# Altium schematic sheet Status Light Control.SchDoc
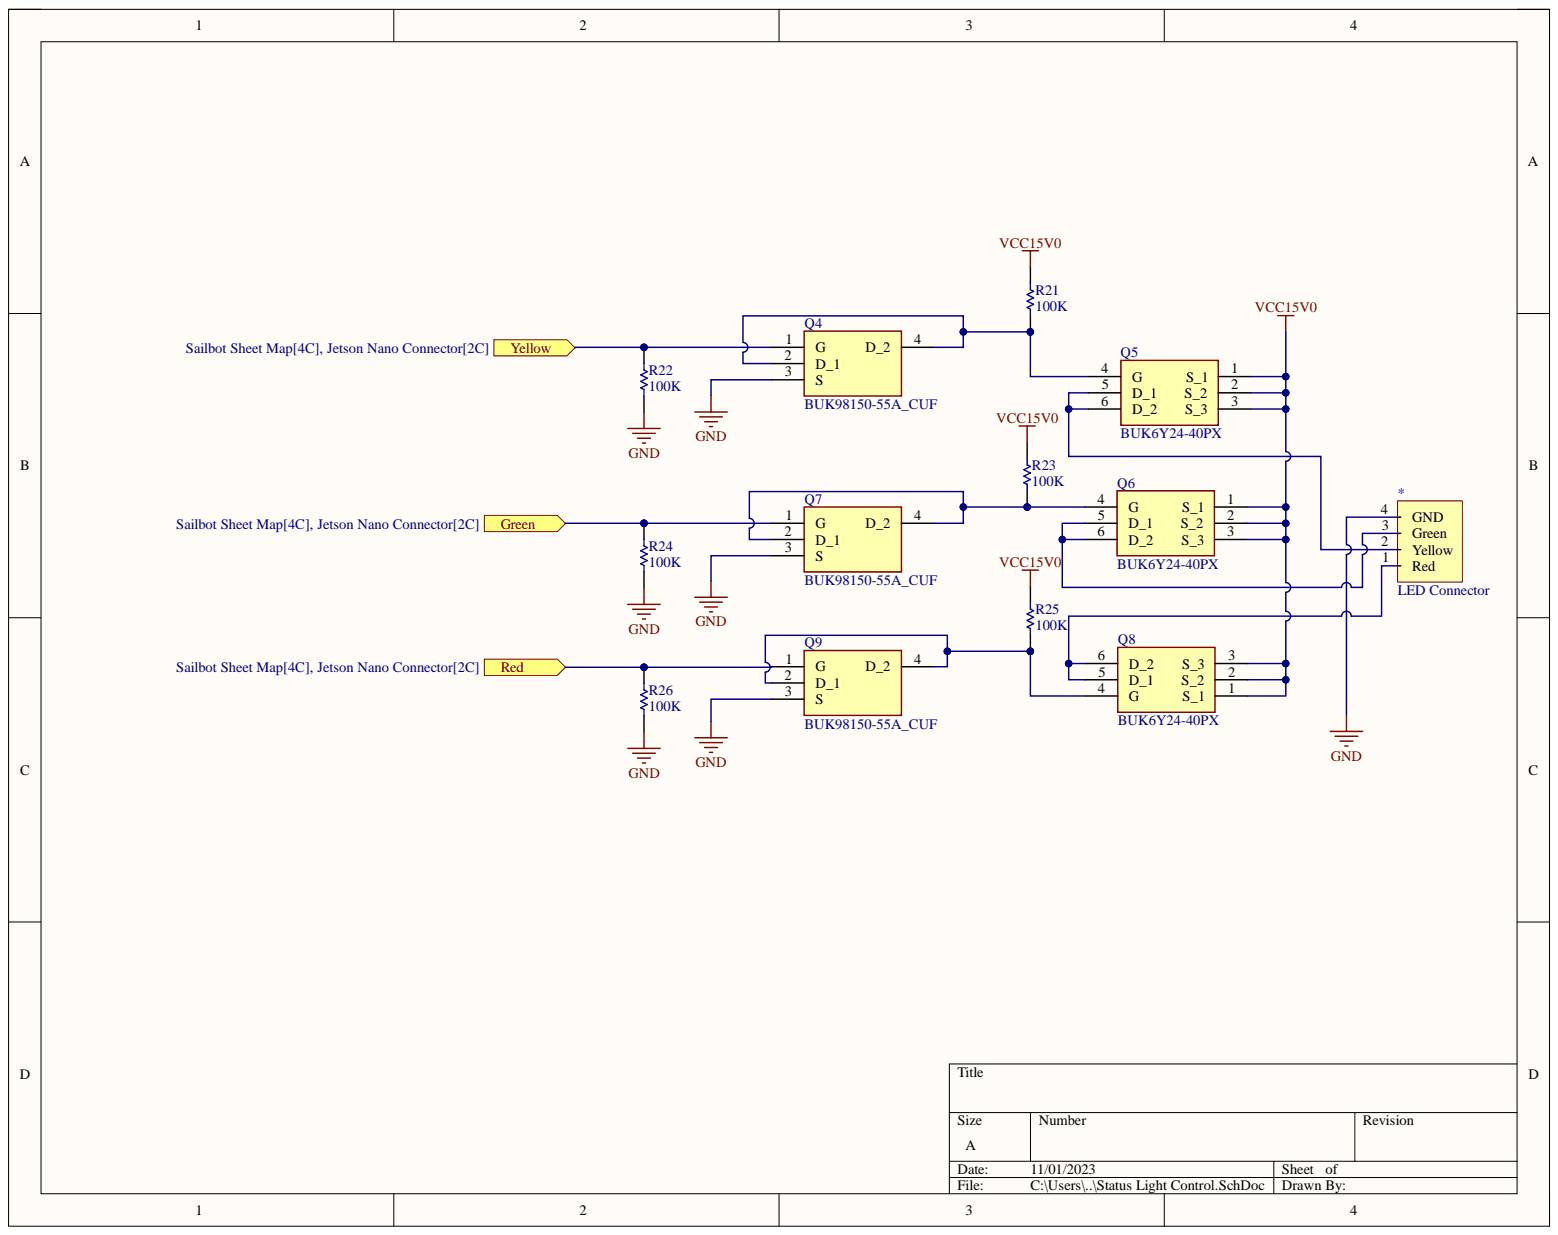
[diagram p0: Status Light Control.SchDoc]

## Parts
*  LED Connector
Q4  BUK98150-55A_CUF  [SOT230P700X180-4N]
Q5  BUK6Y24-40PX  [BUK6Y2440PX]
Q6  BUK6Y24-40PX  [BUK6Y2440PX]
Q7  BUK98150-55A_CUF  [SOT230P700X180-4N]
Q8  BUK6Y24-40PX  [BUK6Y2440PX]
Q9  BUK98150-55A_CUF  [SOT230P700X180-4N]
R21  value 100K  (hidden comment: Resistor)
R22  value 100K  (hidden comment: Resistor)
R23  value 100K  (hidden comment: Resistor)
R24  value 100K  (hidden comment: Resistor)
R25  value 100K  (hidden comment: Resistor)
R26  value 100K  (hidden comment: Resistor)

## Ports
Green, Red, Yellow

## Nets
GND: *.4(GND) Q4.3(S) Q7.3(S) Q9.3(S) R22.1 R24.1 R26.1
VCC15V0: Q5.1(S_1) Q5.2(S_2) Q5.3(S_3) Q6.1(S_1) Q6.2(S_2) Q6.3(S_3) Q8.1(S_1) Q8.2(S_2) Q8.3(S_3) R21.2 R23.2 R25.2
Green: Q7.1(G) R24.2 ; port Green
Red: Q9.1(G) R26.2 ; port Red
Yellow: Q4.1(G) R22.2 ; port Yellow
Net*_1: *.1(Red) Q8.5(D_1) Q8.6(D_2)
Net*_2: *.2(Yellow) Q5.5(D_1) Q5.6(D_2)
Net*_3: *.3(Green) Q6.5(D_1) Q6.6(D_2)
NetQ4_2: Q4.2(D_1) Q4.4(D_2) Q5.4(G) R21.1
NetQ6_4: Q6.4(G) Q7.2(D_1) Q7.4(D_2) R23.1
NetQ8_4: Q8.4(G) Q9.2(D_1) Q9.4(D_2) R25.1

## Library data of the parts
Q4 Q7 Q9 = BUK98150-55A_CUF: Trans MOSFET N-CH 55V 5.5A 4-Pin(3+Tab) SC-73 T/R; Manufacturer_Name: Nexperia; Manufacturer_Part_Number: BUK98150-55A/CUF; Geometry.Height: 1.8mm; Component Kind: Standard; Datasheet Link: https://assets.nexperia.com/documents/data-sheet/BUK98150-55A.pdf; Mouser Part Number: 771-BUK98150-55A/CUF; Mouser Price/Stock: https://www.mouser.co.uk/ProductDetail/Nexperia/BUK98150-55A-CUF?qs=Yna0arPQ0CRUeN6OsRm%252BFA%3D%3D; Library Name: Sailbot Library.SchLib
Q5 Q6 Q8 = BUK6Y24-40PX: NEXPERIA - BUK6Y24-40PX - Power MOSFET, P Channel, 40 V, 39 A, 0.019 ohm, LFPAK56, Surface Mount; Geometry.Height: 1.2mm; Component Kind: Standard; Datasheet Link: https://assets.nexperia.com/documents/data-sheet/BUK6Y24-40P.pdf; Manufacturer_Name: Nexperia; Manufacturer_Part_Number: BUK6Y24-40PX; Mouser Part Number: 771-BUK6Y24-40PX; Mouser Price/Stock: https://www.mouser.co.uk/ProductDetail/Nexperia/BUK6Y24-40PX?qs=vmHwEFxEFR8tyd2WwTEwBg%3D%3D; Library Name: Sailbot Library.SchLib
R21-R26 = Resistor: SimulationGenericComponent: True; TC1: 0; Component Kind: Standard; Description: Resistor; Library Name: Simulation Generic Components.IntLib; Simulation: R_Resistor_Default; TC2: 0
* = LED Connector: Component Kind: Standard; Library Name: Sailbot Library.SchLib
